# Altium schematic sheet Power.SchDoc(Power)
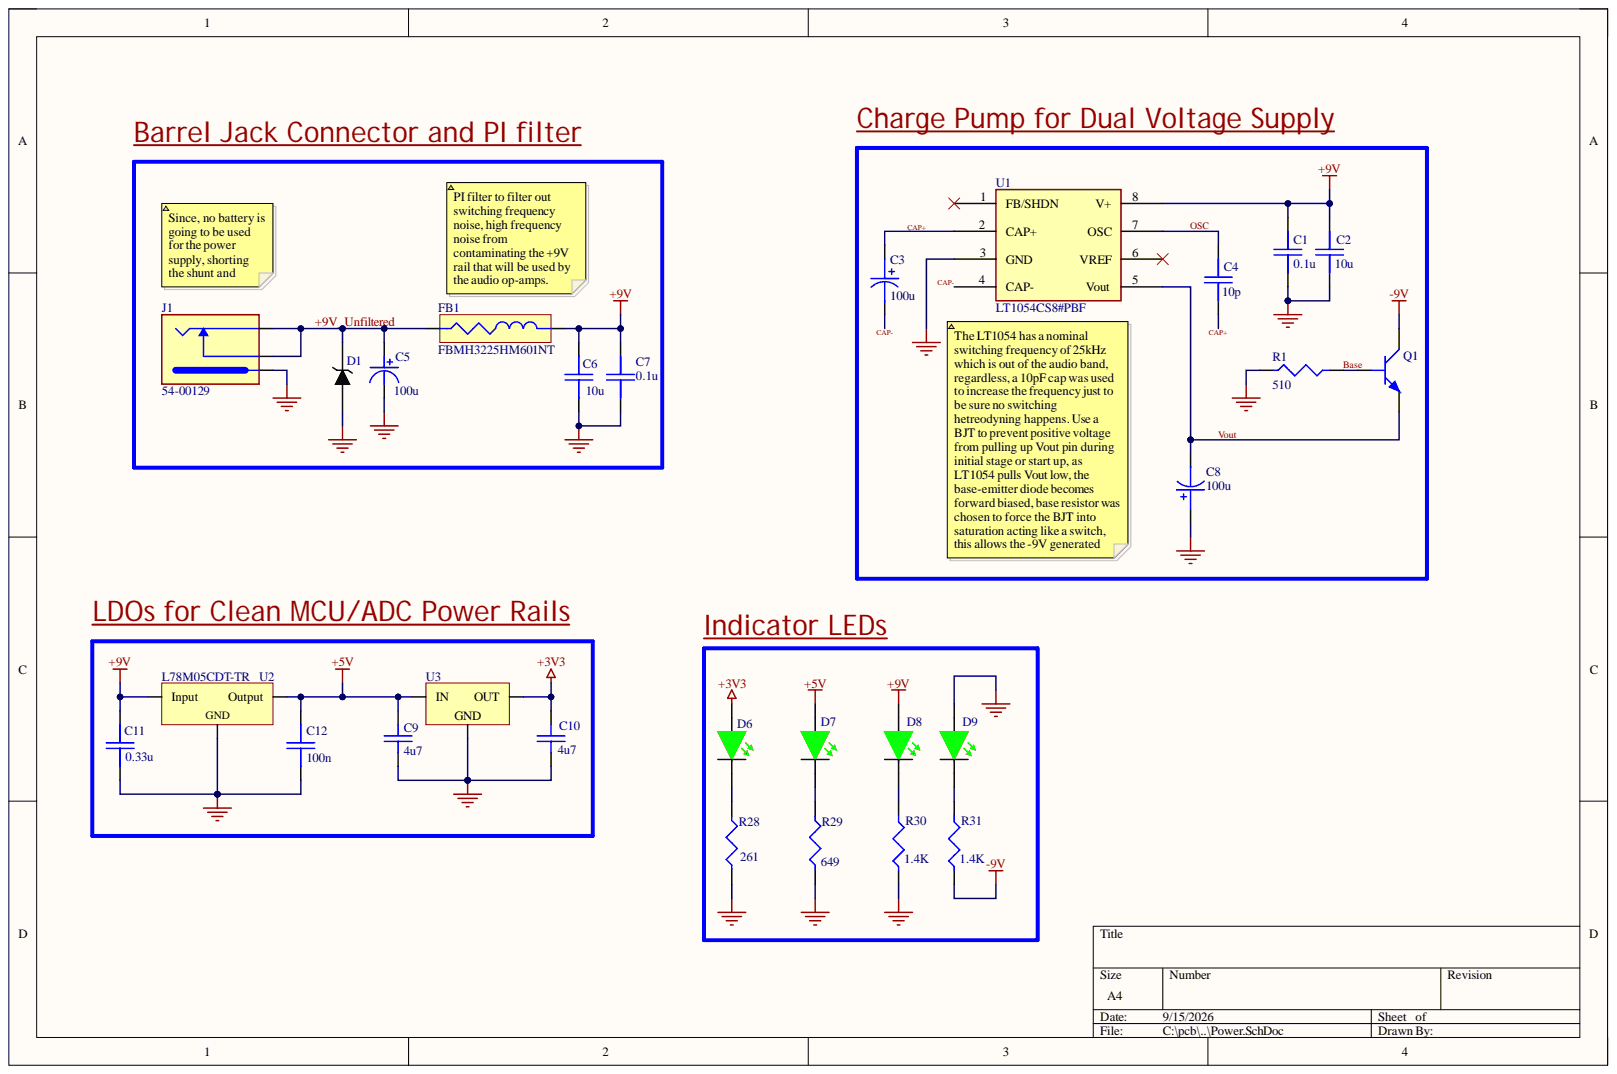
[diagram p0: Power.SchDoc]

## Parts
C1  0.1u  [C0603]
C2  10u  [C1206]
C3  100u  [T491X107K025AT]
C4  10p  [C0805]
C5  100u  [50ZLH100MEFC8X11.5]
C6  10u  [C1210]
C7  0.1u  [C0603]
C8  100u  [T491X107K025AT]
C9  4u7  [C1206_MEDDensity]
C10  4u7  [C1206_MEDDensity]
C11  0.33u  [C1206]
C12  100n  [C1206]
D1  SAC10-E3/54  [SAC10-E3/54]  (value not drawn)
D6  WP1503GT  [WP1503GT]  (value not drawn)
D7  WP1503GT  [WP1503GT]  (value not drawn)
D8  WP1503GT  [WP1503GT]  (value not drawn)
D9  WP1503GT  [WP1503GT]  (value not drawn)
FB1  FBMH3225HM601NT  [FBMH3225HM601NT]
J1  54-00129  [54-00129]
Q1  MMBT2222ALT1G  [MMBT2222ALT1G]  (value not drawn)
R1  510  [R1210]
R28  261  [R0603]
R29  649  [R0603]
R30  1.4K  [R0603]
R31  1.4K  [R0603]
U1  LT1054CS8#PBF  [LT1054CS8#PBF]
U2  L78M05CDT-TR  [L78M05CDT-TR]
U3  LDO, AP7361C-33ER-13  [AP7361C-33ER-13_SOT223R]  (value not drawn)

## Nets
+3V3: C10.1 D6.1 U3.2
+5V: C9.1 C12.2 D7.1 U2.3 U3.3
+9V: C1.2 C2.2 C6.2 C7.2 C11.2 D8.1 FB1.2 U1.8(V+) U2.1
-9V: Q1.3 R31.1
GND: C1.1 C2.1 C5.2 C6.1 C7.1 C8.1 C9.2 C10.2 C11.1 C12.1 D1.2 D9.1 J1.1 R1.1 R28.1 R29.1 R30.1 U1.3(GND) U2.2 U3.1
+9V_Unfiltered: C5.1 D1.1 FB1.1 J1.2 J1.3
Base: Q1.1 R1.2
CAP+: C3.1 C4.1 U1.2(CAP+)
CAP-: C3.2 U1.4(CAP-)
OSC: C4.2 U1.7(OSC)
Vout: C8.2 Q1.2 U1.5(Vout)
NetD6_2: D6.2 R28.2
NetD7_2: D7.2 R29.2
NetD8_2: D8.2 R30.2
NetD9_2: D9.2 R31.2

Not connected: U1 1(FB/SHDN) 6(VREF)
